# Altium schematic sheet Sensor.SchDoc
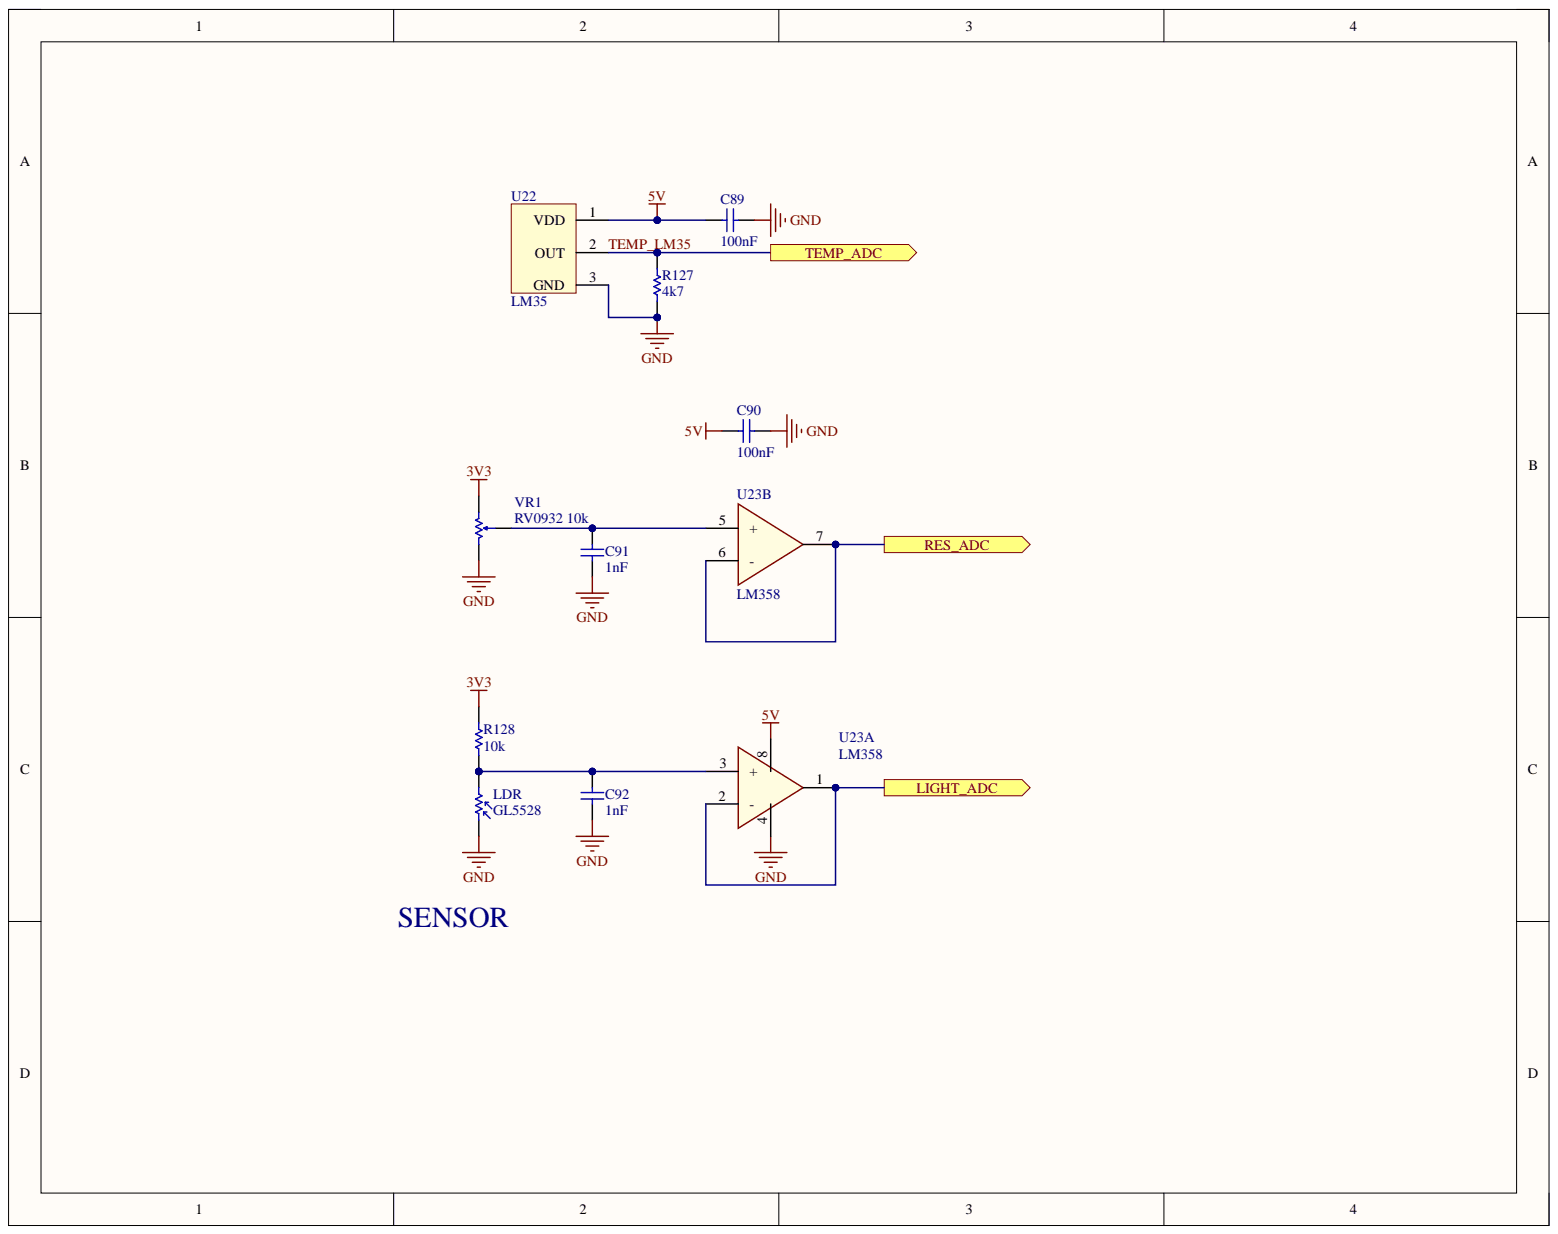
[diagram p0: Sensor.SchDoc]

## Parts
C89  100nF  [C 0603 (1608)]
C90  100nF  [C 0603 (1608)]
C91  1nF  [C 0603 (1608)]
C92  1nF  [C 0603 (1608)]
LDR  GL5528  [R PHOTO SMALL]
R127  4k7  [R 0603 (1608)]
R128  10k  [R 0603 (1608)]
U22  LM35  [TO-92]
U23  LM358  [SOIC-8]  (parts A,B)
VR1  RV0932 10k  [RV09AVF]

## Ports
LIGHT_ADC, RES_ADC, TEMP_ADC

## Nets
3V3: R128.2 VR1.3
5V: C89.1 C90.2 U22.1 U23.8
GND: C89.2 C90.1 C91.2 C92.2 LDR.1 R127.2 U22.3 U23.4 VR1.1
LIGHT_ADC: U23.1 U23.2(-) ; port LIGHT_ADC
RES_ADC: U23.6(-) U23.7 ; port RES_ADC
TEMP_LM35: R127.1 U22.2 ; port TEMP_ADC
NetC91_1: C91.1 U23.5(+) VR1.2
NetC92_1: C92.1 LDR.2 R128.1 U23.3(+)

## Library data of the parts
C89-C92 = Capacitor NP: Capacitor non-pol; Component Kind: Standard; Library Name: BKIT4U_LIB.SchLib
LDR = Light Sensor: Photosensitive Resistor 5537; Component Kind: Standard; LatestRevisionDate: 17-Jul-2002; LatestRevisionNote: Re-released for DXP Platform.; Library Name: BKIT4U_LIB.SchLib; PackageReference: AXIAL-0.3; Published: 8-Jun-2000; Publisher: Altium Limited
R127 R128 = Resistor: Component Kind: Standard; Description: Resistor; LatestRevisionDate: 17-Jul-2002; LatestRevisionNote: Re-released for DXP Platform.; Library Name: BKIT4U_LIB.SchLib; PackageReference: AXIAL-0.3; Published: 8-Jun-2000; Publisher: Altium Limited
U22 = LM35: High Precision  1-Wire® Digital Thermometer; Component Kind: Standard; Library Name: BKIT4U_LIB.SchLib
U23 = LM358: Low Power Dual Operational Amplifiers; Component Kind: Standard; Library Name: BKIT4U_LIB.SchLib
VR1 = Resistor Variable: Component Kind: Standard; Description: Resistor Variable; Library Name: BKIT4U_LIB.SchLib
